# Altium schematic sheet ACDC.SchDoc
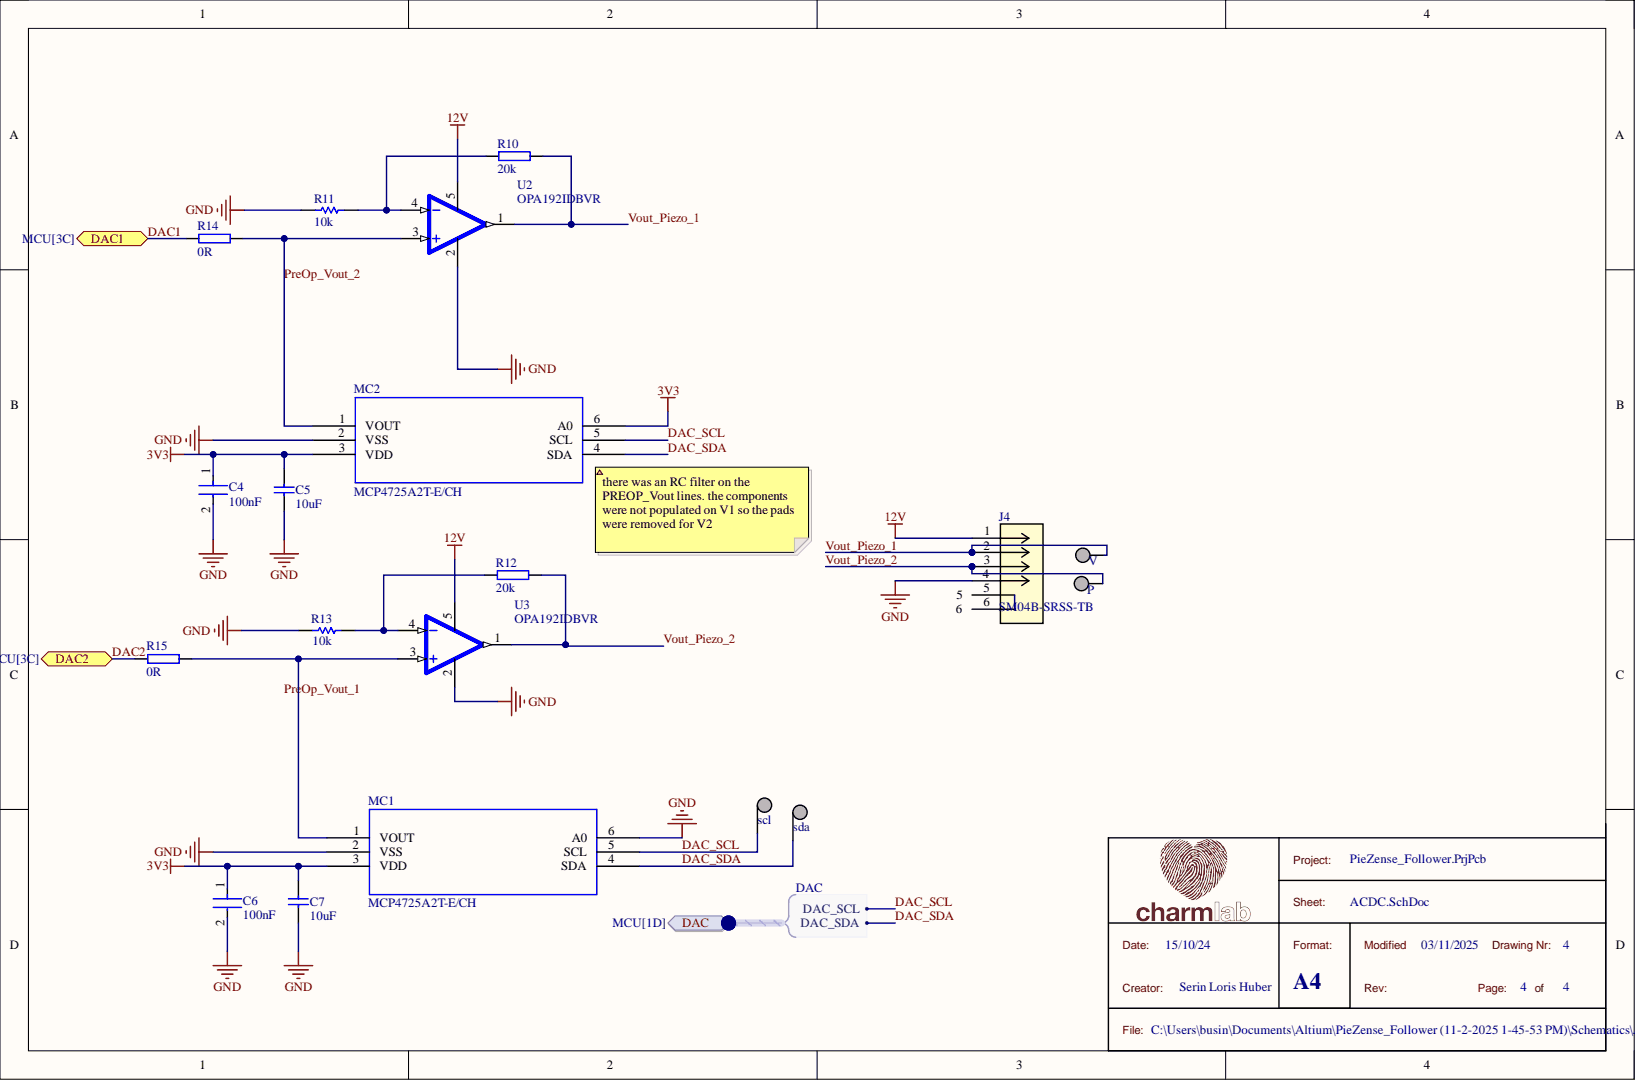
[diagram p0: ACDC.SchDoc]

## Parts
C4  100nF  [FP-GRM155-0_05-MFG]
C5  10uF  [CAP0402]
C6  100nF  [FP-GRM155-0_05-MFG]
C7  10uF  [CAP0402]
J4  SM04B-SRSS-TB  [CONN_SM04B-SRSS-TB_JST]
MC1  MCP4725A2T-E/CH  [SOT-23-6_MC]
MC2  MCP4725A2T-E/CH  [SOT-23-6_MC]
P  Test point  [TEST_POINT]  (value not drawn)  (no BOM)
R10  20k  [RES0402]
R11  10k  [RESC1005X40X25ML05T10]
R12  20k  [RES0402]
R13  10k  [RESC1005X40X25ML05T10]
R14  0R  [RES0402]
R15  0R  [RES0402]
scl  Test point  [TEST_POINT]  (value not drawn)  (no BOM)
sda  Test point  [TEST_POINT]  (value not drawn)  (no BOM)
U2  OPA192IDBVR  [SOT95P280X145-5N]
U3  OPA192IDBVR  [SOT95P280X145-5N]
V  Test point  [TEST_POINT]  (value not drawn)  (no BOM)

## Ports
DAC, DAC1, DAC2, DAC.DAC_SCL, DAC.DAC_SDA

## Nets
3V3: C4.1 C5.2 C6.1 C7.2 MC1.3(VDD) MC2.3(VDD) MC2.6(A0)
12V: J4.1 U2.5 U3.5
GND: C4.2 C5.1 C6.2 C7.1 J4.4 MC1.2(VSS) MC1.6(A0) MC2.2(VSS) R11.1 R13.1 U2.2 U3.2
DAC1: R14.1 ; port DAC1
DAC2: R15.1 ; port DAC2
DAC_SCL: MC1.5(SCL) MC2.5(SCL) scl.1 ; port DAC
DAC_SDA: MC1.4(SDA) MC2.4(SDA) sda.1 ; port DAC
PreOp_Vout_2: MC2.1(VOUT) R14.2 U2.3
Vout_Piezo_1: J4.2 R10.2 U2.1 V.1
Vout_Piezo_2: J4.3 P.1 R12.2 U3.1
NetJ4_5: J4.5 J4.6
NetMC1_1: MC1.1(VOUT) R15.2 U3.3
NetR10_1: R10.1 R11.2 U2.4
NetR12_1: R12.1 R13.2 U3.4
PreOp_Vout_1:

## Library data of the parts
C4 C6 = CMP-2008-04108-2: Chip Multilayer Ceramic Capacitors for General Purpose, 0402, 0.10uF, X5R, 15%, 10%, 50V; Capacitance: 100nF; Case Code (Imperial): 0402; Case Code (Metric): 1005; Case/Package: 0402; Component Kind: Standard; Depth: 0.5mm; Dielectric: X5R; Height: 0.5mm; Lead Free: Lead Free; Length: 1mm; Library Name: Altium Content Vault; Max Operating Temperature: 85°C; Min Operating Temperature: -55°C; Mount: Surface Mount; Packaging: Tape and Reel; REACH SVHC: No SVHC; Thickness: 0.022inch; Tolerance: 10%; Voltage: 50V; Voltage Rating: 50V; Voltage Rating (DC): 50V; Width: 0.02inch
C5 C7 = GRM155R61A106ME11D: CAP CER 10uF 10V X5R 0402; Manufacturer: Murata Electronics; Manufacturer Part Number: GRM155R71H103KA88D; Part Number: GRM033R70J103JA01D; Capacitor Type: Ceramic; Multilayer: Yes; Negative Tolerance: 10%; Rated DC Voltage (URdc): 50V; Temperature Characteristics Code: X7R; Tolerance: ±5%; Voltage - Rated: 6.3V; Applications: General Purpose; Capacitance: 10uF; Category: Ceramic Capacitors; Component Kind: Standard; ComponentLink1Description: Datasheet; ComponentLink1URL: https://search.murata.co.jp/Ceramy/image/img/A01X/G101/ENG/GRM033R70J103JA01-01.pdf; ComponentLink2Description: DigiKey Link; ComponentLink2URL: http://digikey.com/product-detail/en/murata-electronics/GRM033R70J103JA01D/490-16599-1-ND/7595523; ComponentLink3Description: https://psearch.en.murata.com:443/capacitor/product/GRM155R71H103KA88%23.pdf?src-supplier=IHS+Markit; ComponentLink3URL: https://psearch.en.murata.com:443/capacitor/product/GRM155R71H103KA88%23.pdf?src-supplier=IHS+Markit; ComponentLink4Description: https://datasheet.ciiva.com/22698/1747449-22698420.pdf?src-supplier=Element14; ComponentLink4URL: https://datasheet.ciiva.com/22698/1747449-22698420.pdf?src-supplier=Element14; ComponentLink5Description: https://datasheet.ciiva.com/19476/1856433-19476546.pdf?src-supplier=Jameco; ComponentLink5URL: https://datasheet.ciiva.com/19476/1856433-19476546.pdf?src-supplier=Jameco; ComponentLink6Description: https://datasheet.ciiva.com/129/product-129810.pdf?src-supplier=Digi-Key; ComponentLink6URL: https://datasheet.ciiva.com/129/product-129810.pdf?src-supplier=Digi-Key; ComponentLink7Description: https://datasheet.ciiva.com/11786/0900766b80ef921d-11786340.pdf?src-supplier=RSComponents; ComponentLink7URL: https://datasheet.ciiva.com/11786/0900766b80ef921d-11786340.pdf?src-supplier=RSComponents; ComponentLink8Description: https://datasheet.ciiva.com/18100/getdatasheetpartid-27434-18100660.pdf?src-supplier=Verical; ComponentLink8URL: https://datasheet.ciiva.com/18100/getdatasheetpartid-27434-18100660.pdf?src-supplier=Verical; ComponentLink9Description: https://datasheet.ciiva.com/29199/454225-da-01-en-vs-kondensator-0402-29199740.pdf?src-supplier=Conrad; ComponentLink9URL: https://datasheet.ciiva.com/29199/454225-da-01-en-vs-kondensator-0402-29199740.pdf?src-supplier=Conrad; ComponentLink10Description: https://datasheet.ciiva.com/129/grm155r71h103ka88-01-129811.pdf?src-supplier=Digi-Key; ComponentLink10URL: https://datasheet.ciiva.com/129/grm155r71h103ka88-01-129811.pdf?src-supplier=Digi-Key; ComponentLink11Description: https://datasheet.ciiva.com/129/grm155r71h103ka88-01-129812.pdf?src-supplier=Digi-Key; ComponentLink11URL: https://datasheet.ciiva.com/129/grm155r71h103ka88-01-129812.pdf?src-supplier=Digi-Key; ComponentLink12Description: https://datasheet.ciiva.com/5410/product-5410990.pdf?src-supplier=Mouser; ComponentLink12URL: https://datasheet.ciiva.com/5410/product-5410990.pdf?src-supplier=Mouser; ComponentLink13Description: https://datasheet.ciiva.com/16495/product-16495290.pdf?src-supplier=Future+Electronics; ComponentLink13URL: https://datasheet.ciiva.com/16495/product-16495290.pdf?src-supplier=Future+Electronics; ComponentLink14Description: https://datasheet.ciiva.com:443/pdfs/VipMasterIC/IC/MURE/MURES89421/MURES89636-1.pdf?src-supplier=IHS+Markit; ComponentLink14URL: https://datasheet.ciiva.com:443/pdfs/VipMasterIC/IC/MURE/MURES89421/MURES89636-1.pdf?src-supplier=IHS+Markit; ComponentLink15Description: https://datasheet.ciiva.com/6073/c02e-6073016.pdf?src-supplier=Farnell; ComponentLink15URL: https://datasheet.ciiva.com/6073/c02e-6073016.pdf?src-supplier=Farnell; ComponentLink16Description: https://datasheet.ciiva.com/22619/c02e-22619672.pdf?src-supplier=Element14; ComponentLink16URL: https://datasheet.ciiva.com/22619/c02e-22619672.pdf?src-supplier=Element14; ComponentLink17Description: https://datasheet.ciiva.com:443/pdfs/VipMasterIC/IC/MURE/MURE-S-A0006500741/MURE-S-A0006500741-1.pdf?src-supplier=IHS+Markit; ComponentLink17URL: https://datasheet.ciiva.com:443/pdfs/VipMasterIC/IC/MURE/MURE-S-A0006500741/MURE-S-A0006500741-1.pdf?src-supplier=IHS+Markit; Device Package: 0201 (0603 Metric); Dielectric Material: Ceramic; Height: 0.5mm; JESD-609 Code: e3; LastUpdated: 02/09/2020 01:00:04; Length: 1mm; Lifecycle Status: Active; Max Operating Temperature: 125°C; Min Operating Temperature: -55°C; Minimum Order: 1; Mount: Surface Mount; Mounting Type: Surface Mount, MLCC; Number of Pins: 2; Operating Temperature: -55°C ~ 125°C; Package / Case: 0201 (0603 Metric); Package Shape: Rectangular; Package Style: SMTMeter; Packaging: CutTape; Part Status: Active; PartId: 174; Positive Tolerance: 10%; Price: 0.10; Pricing 1: 1=0.12000, 10=0.08200, 50=0.08200, 100=0.04800, 1000=0.04800, 10000=0.04700 (USD); Pricing 2: 1=0.16000, 10=0.07100, 50=0.07100, 100=0.05400, 1000=0.03300, 10000=0.02500 (USD); REACH SVHC: Yes; Reach SVHC Compliant: Yes; RoHS: Yes; RoHS Compliant: Yes; Series: GRM; Size / Dimension: 0.024" L x 0.012" W (0.60mm x 0.30mm); Size Code: 0402; Stock 1: 1376480; Stock 2: 855140; Supplier 1: Mouser; Supplier 2: Mouser; Supplier 3: Digikey; Supplier 4: Digikey; Supplier 5: Digikey; Supplier Part Number 1: 81-GRM155R61A106ME1D; Supplier Part Number 2: 81-GRM188R60J106ME47; Supplier Part Number 3: 490-3896-1-ND; Supplier Part Number 4: 490-3896-6-ND; Supplier Part Number 5: 490-3896-2-ND; Temperature Coefficient: X7R; Terminal Finish: Nickel; Termination: Wraparound; Thickness (Max): 0.013" (0.33mm); Value: 10uF; Width: 0.5mm; Library Name: schuluka.SCHLIB
J4 = CMP-008-000001-3: No Description Available; Mfr_Name: JST; Component Kind: Standard; Design Item ID: SM04B-SRSS-TB; RefDes: RefDes; Source: SM04B-SRSS-TB.SchLib; Type: Type; Library Name: CHARM_PieZense
MC1 MC2 = MCP4725A2T-E/CH: 12 Bit Digital to Analog Converter 1 SOT-23-6; MF: Microchip; Availability: Unavailable; Component Kind: Standard; MP: MCP4725A2T-E/CH; Package: SOT-23-6 Microchip; Price: None; SnapEDA_Link: https://www.snapeda.com/parts/MCP4725A2T-E/CH/Microchip/view-part/108042/?ref=snap; Supplier 1: Digikey; Supplier 2: Digikey; Supplier 3: Digikey; Supplier 4: Mouser; Supplier Part Number 1: MCP4725A2T-E/CHCT-ND; Supplier Part Number 2: MCP4725A2T-E/CHDKR-ND; Supplier Part Number 3: MCP4725A2T-E/CHTR-ND; Supplier Part Number 4: 579-MCP4725A2T-E/CH; Library Name: huberser.SchLib
R10 R12 = CRCW040220K0FKEDC: RES SMD 20k OHM  0.063W 0402; Manufacturer: Panasonic Electronic Components; Manufacturer Part Number: ERJ-1GNJ334C; Part Number: ERJ-1GNF5110C; Package Height: 0.35mm; Package Length: 1mm; Package Width: 0.5mm; Power (Watts): 0.05W, 1/20W; Rated Power Dissipation (P): 63mW; Rated Temperature: 70°C; Reference Standard: AEC-Q200; Resistance: 20k Ohms; Temperature Coefficient: ±200ppm/°C; Tolerance: ±1%; Working Voltage: 50V; Category: Chip SMD Resistors; Component Kind: Standard; ComponentLink1Description: Datasheet; ComponentLink1URL: https://industrial.panasonic.com/cdbs/www-data/pdf/RDA0000/AOA0000C304.pdf; ComponentLink2Description: DigiKey Link; ComponentLink2URL: http://digikey.com/product-detail/en/panasonic-electronic-components/ERJ-1GNF5110C/P123054CT-ND/8343047; ComponentLink3Description: http://www.onlinecomponents.com/datasheet/erj-1gnj334c.aspx?p=44467782&src-supplier=Onlinecomponents.com; ComponentLink3URL: http://www.onlinecomponents.com/datasheet/erj-1gnj334c.aspx?p=44467782&src-supplier=Onlinecomponents.com; ComponentLink4Description: https://b2b-api.panasonic.eu/file_stream/pids/fileversion/1242?src-supplier=Digi-Key; ComponentLink4URL: https://b2b-api.panasonic.eu/file_stream/pids/fileversion/1242?src-supplier=Digi-Key; ComponentLink5Description: http://industrial.panasonic.com/www-cgi/jvcr13pz.cgi?E+PZ+3+AOA0002+ERJ1GNJ334C+7+WW?src-supplier=Digi-Key; ComponentLink5URL: http://industrial.panasonic.com/www-cgi/jvcr13pz.cgi?E+PZ+3+AOA0002+ERJ1GNJ334C+7+WW?src-supplier=Digi-Key; ComponentLink6Description: http://industrial.panasonic.com/www-cgi/jvcr13pz.cgi?E+PZ+3+AOA0002+ERJ1GNJ120C+7+WW?src-supplier=Mouser; ComponentLink6URL: http://industrial.panasonic.com/www-cgi/jvcr13pz.cgi?E+PZ+3+AOA0002+ERJ1GNJ120C+7+WW?src-supplier=Mouser; ComponentLink7Description: https://datasheet.ciiva.com:443/pdfs/VipMasterIC/IC/VISH/VISH-S-A0007266705/VISH-S-A0007266705-1.pdf?src-supplier=IHS+Markit; ComponentLink7URL: https://datasheet.ciiva.com:443/pdfs/VipMasterIC/IC/VISH/VISH-S-A0007266705/VISH-S-A0007266705-1.pdf?src-supplier=IHS+Markit; ComponentLink8Description: https://datasheet.ciiva.com/2461/dcrcwe3-2461974.pdf?src-supplier=Digi-Key; ComponentLink8URL: https://datasheet.ciiva.com/2461/dcrcwe3-2461974.pdf?src-supplier=Digi-Key; ComponentLink9Description: https://datasheet.ciiva.com/2461/dcrcwe3-2461975.pdf?src-supplier=Digi-Key; ComponentLink9URL: https://datasheet.ciiva.com/2461/dcrcwe3-2461975.pdf?src-supplier=Digi-Key; ComponentLink10Description: https://datasheet.ciiva.com/2461/dcrcwe3-2461976.pdf?src-supplier=Digi-Key; ComponentLink10URL: https://datasheet.ciiva.com/2461/dcrcwe3-2461976.pdf?src-supplier=Digi-Key; ComponentLink11Description: https://datasheet.ciiva.com/7743/dcrcwe3-7743416.pdf?src-supplier=Element14; ComponentLink11URL: https://datasheet.ciiva.com/7743/dcrcwe3-7743416.pdf?src-supplier=Element14; ComponentLink12Description: https://datasheet.ciiva.com/16771/70201119-16771096.pdf?src-supplier=Allied+Electronics; ComponentLink12URL: https://datasheet.ciiva.com/16771/70201119-16771096.pdf?src-supplier=Allied+Electronics; ComponentLink13Description: https://datasheet.ciiva.com/7743/dcrcwe3-7743417.pdf?src-supplier=Element14; ComponentLink13URL: https://datasheet.ciiva.com/7743/dcrcwe3-7743417.pdf?src-supplier=Element14; ComponentLink14Description: https://datasheet.ciiva.com/16761/70201119-16761947.pdf?src-supplier=Allied+Electronics; ComponentLink14URL: https://datasheet.ciiva.com/16761/70201119-16761947.pdf?src-supplier=Allied+Electronics; ComponentLink15Description: https://datasheet.ciiva.com/3255/dcrcwe3-3255964.pdf?src-supplier=Avnet; ComponentLink15URL: https://datasheet.ciiva.com/3255/dcrcwe3-3255964.pdf?src-supplier=Avnet; ComponentLink16Description: https://datasheet.ciiva.com/3255/dcrcwe3-3255965.pdf?src-supplier=Avnet; ComponentLink16URL: https://datasheet.ciiva.com/3255/dcrcwe3-3255965.pdf?src-supplier=Avnet; ComponentLink17Description: https://datasheet.ciiva.com:443/pdfs/VipMasterIC/IC/VISH/VISH-S-A0003599164/VISH-S-A0003599164-1.pdf?src-supplier=IHS+Markit; ComponentLink17URL: https://datasheet.ciiva.com:443/pdfs/VipMasterIC/IC/VISH/VISH-S-A0003599164/VISH-S-A0003599164-1.pdf?src-supplier=IHS+Markit; ComponentLink18Description: http://www.vishay.com/docs/28773/crcwce3.pdf?src-supplier=Digi-Key; ComponentLink18URL: http://www.vishay.com/docs/28773/crcwce3.pdf?src-supplier=Digi-Key; Composition: Thick Film; Construction: Chip; Device Package: 0201 (0603 Metric); Features: Automotive AEC-Q200; Height - Seated (Max): 0.010" (0.26mm); JESD-609 Code: e3; LastUpdated: 02/09/2020 01:06:20; Lifecycle Status: Active; Max Operating Temperature: 155°C; Min Operating Temperature: -55°C; Minimum Order: 1; Mount: Surface Mount; Number of Pins: 2; Number of Terminations: 2; Operating Temperature: -55°C ~ 125°C; Package / Case: 0201 (0603 Metric); Package Shape: Rectangular; Package Style: SMTMeter; Packaging: TapeAndReel; Part Status: Active; PartId: 1262; Price: 0.01; Pricing 1: 1=0.10000, 10=0.03500, 50=0.03500, 100=0.01500, 1000=0.00700, 2500=0.00600, 5000=0.00500, 10000=0.00500 (USD); Pricing 2: 1=0.10000, 10=0.03400, 50=0.03400, 100=0.01400, 1000=0.00700, 2500=0.00600, 5000=0.00500, 10000=0.00400 (USD); Ratings: AEC-Q200; REACH SVHC: Yes; Resistor Type: FIXED RESISTOR; RoHS: Yes; RoHS Compliant: Yes; Series: ERJ; Size / Dimension: 0.024" L x 0.012" W (0.60mm x 0.30mm); Size Code: 0402; Stock 2: 9502; Supplier 1: Mouser; Supplier Device Package: 0201; Supplier Part Number 1: 71-CRCW040220K0FKEDC; Technology: METAL GLAZE/THICK FILM; Terminal Finish: Nickel; Termination: Wraparound; Value: 20k; Library Name: schuluka.SCHLIB
  R12: Supplier 2: Mouser; Supplier 3: Digikey; Supplier 4: Digikey; Supplier 5: Digikey; Supplier Part Number 2: 667-ERA-3AEB203V; Supplier Part Number 3: P20KDBCT-ND; Supplier Part Number 4: P20KDBDKR-ND; Supplier Part Number 5: P20KDBTR-ND
R11 R13 = CMP-2002-03043-1: Manufacturer: Vishay Dale; Part Number: CRCW040210K0FKEDHP; Component Kind: Standard; Library Name: Altium Content Vault
R14 R15 = CRCW04020000Z0EDC: RES SMD 0 OHM  0.063W 0402; Manufacturer: Panasonic Electronic Components; Manufacturer Part Number: ERJ-1GNJ334C; Part Number: ERJ-1GNF5110C; Package Height: 0.35mm; Package Length: 1mm; Package Width: 0.5mm; Power (Watts): 0.05W, 1/20W; Rated Power Dissipation (P): 63mW; Rated Temperature: 70°C; Reference Standard: AEC-Q200; Resistance: 0R Ohms; Temperature Coefficient: ±200ppm/°C; Tolerance: ±1%; Working Voltage: 50V; Category: Chip SMD Resistors; Component Kind: Standard; ComponentLink1Description: Datasheet; ComponentLink1URL: https://industrial.panasonic.com/cdbs/www-data/pdf/RDA0000/AOA0000C304.pdf; ComponentLink2Description: DigiKey Link; ComponentLink2URL: http://digikey.com/product-detail/en/panasonic-electronic-components/ERJ-1GNF5110C/P123054CT-ND/8343047; ComponentLink3Description: http://www.onlinecomponents.com/datasheet/erj-1gnj334c.aspx?p=44467782&src-supplier=Onlinecomponents.com; ComponentLink3URL: http://www.onlinecomponents.com/datasheet/erj-1gnj334c.aspx?p=44467782&src-supplier=Onlinecomponents.com; ComponentLink4Description: https://b2b-api.panasonic.eu/file_stream/pids/fileversion/1242?src-supplier=Digi-Key; ComponentLink4URL: https://b2b-api.panasonic.eu/file_stream/pids/fileversion/1242?src-supplier=Digi-Key; ComponentLink5Description: http://industrial.panasonic.com/www-cgi/jvcr13pz.cgi?E+PZ+3+AOA0002+ERJ1GNJ334C+7+WW?src-supplier=Digi-Key; ComponentLink5URL: http://industrial.panasonic.com/www-cgi/jvcr13pz.cgi?E+PZ+3+AOA0002+ERJ1GNJ334C+7+WW?src-supplier=Digi-Key; ComponentLink6Description: http://industrial.panasonic.com/www-cgi/jvcr13pz.cgi?E+PZ+3+AOA0002+ERJ1GNJ120C+7+WW?src-supplier=Mouser; ComponentLink6URL: http://industrial.panasonic.com/www-cgi/jvcr13pz.cgi?E+PZ+3+AOA0002+ERJ1GNJ120C+7+WW?src-supplier=Mouser; ComponentLink7Description: https://datasheet.ciiva.com:443/pdfs/VipMasterIC/IC/VISH/VISH-S-A0007266705/VISH-S-A0007266705-1.pdf?src-supplier=IHS+Markit; ComponentLink7URL: https://datasheet.ciiva.com:443/pdfs/VipMasterIC/IC/VISH/VISH-S-A0007266705/VISH-S-A0007266705-1.pdf?src-supplier=IHS+Markit; ComponentLink8Description: https://datasheet.ciiva.com/11808/0900766b80d98824-11808638.pdf?src-supplier=RSComponents; ComponentLink8URL: https://datasheet.ciiva.com/11808/0900766b80d98824-11808638.pdf?src-supplier=RSComponents; ComponentLink9Description: https://datasheet.ciiva.com/2463/dcrcwe3-2463030.pdf?src-supplier=Digi-Key; ComponentLink9URL: https://datasheet.ciiva.com/2463/dcrcwe3-2463030.pdf?src-supplier=Digi-Key; ComponentLink10Description: https://datasheet.ciiva.com/46385/1697337-46385499.pdf?src-supplier=Element14; ComponentLink10URL: https://datasheet.ciiva.com/46385/1697337-46385499.pdf?src-supplier=Element14; ComponentLink11Description: https://datasheet.ciiva.com/3256/dcrcwe3-3256140.pdf?src-supplier=Avnet; ComponentLink11URL: https://datasheet.ciiva.com/3256/dcrcwe3-3256140.pdf?src-supplier=Avnet; ComponentLink12Description: https://datasheet.ciiva.com/16721/70201119-16721452.pdf?src-supplier=Allied+Electronics; ComponentLink12URL: https://datasheet.ciiva.com/16721/70201119-16721452.pdf?src-supplier=Allied+Electronics; ComponentLink13Description: https://datasheet.ciiva.com/2463/dcrcwe3-2463028.pdf?src-supplier=Digi-Key; ComponentLink13URL: https://datasheet.ciiva.com/2463/dcrcwe3-2463028.pdf?src-supplier=Digi-Key; ComponentLink14Description: https://datasheet.ciiva.com/2463/dcrcwe3-2463029.pdf?src-supplier=Digi-Key; ComponentLink14URL: https://datasheet.ciiva.com/2463/dcrcwe3-2463029.pdf?src-supplier=Digi-Key; ComponentLink15Description: https://datasheet.ciiva.com/7743/dcrcwe3-7743477.pdf?src-supplier=Element14; ComponentLink15URL: https://datasheet.ciiva.com/7743/dcrcwe3-7743477.pdf?src-supplier=Element14; ComponentLink16Description: https://datasheet.ciiva.com/26316/fld-crcw-e3-data-en-26316646.pdf?src-supplier=Distrelec; ComponentLink16URL: https://datasheet.ciiva.com/26316/fld-crcw-e3-data-en-26316646.pdf?src-supplier=Distrelec; ComponentLink17Description: https://datasheet.ciiva.com/3256/dcrcwe3-3256139.pdf?src-supplier=Avnet; ComponentLink17URL: https://datasheet.ciiva.com/3256/dcrcwe3-3256139.pdf?src-supplier=Avnet; ComponentLink18Description: https://datasheet.ciiva.com/16287/dcrcwe3-16287807.pdf?src-supplier=Future+Electronics; ComponentLink18URL: https://datasheet.ciiva.com/16287/dcrcwe3-16287807.pdf?src-supplier=Future+Electronics; ComponentLink19Description: https://datasheet.ciiva.com:443/pdfs/VipMasterIC/IC/VISH/VISH-S-A0003599164/VISH-S-A0003599164-1.pdf?src-supplier=IHS+Markit; ComponentLink19URL: https://datasheet.ciiva.com:443/pdfs/VipMasterIC/IC/VISH/VISH-S-A0003599164/VISH-S-A0003599164-1.pdf?src-supplier=IHS+Markit; ComponentLink20Description: http://www.vishay.com/docs/28773/crcwce3.pdf?src-supplier=Digi-Key; ComponentLink20URL: http://www.vishay.com/docs/28773/crcwce3.pdf?src-supplier=Digi-Key; ComponentLink21Description: http://www.vishay.com/docs/20035/dcrcwe3.pdf?src-supplier=Avnet; ComponentLink21URL: http://www.vishay.com/docs/20035/dcrcwe3.pdf?src-supplier=Avnet; Composition: Thick Film; Construction: Chip; Device Package: 0201 (0603 Metric); Features: Automotive AEC-Q200; Height - Seated (Max): 0.010" (0.26mm); JESD-609 Code: e3; LastUpdated: 02/09/2020 01:06:20; Lifecycle Status: Active; Max Operating Temperature: 155°C; Min Operating Temperature: -55°C; Minimum Order: 1; Mount: Surface Mount; Number of Pins: 2; Number of Terminations: 2; Operating Temperature: -55°C ~ 125°C; Package / Case: 0201 (0603 Metric); Package Shape: Rectangular; Package Style: SMTMeter; Packaging: TapeAndReel; Part Status: Active; PartId: 1262; Price: 0.01; Pricing 1: 1=0.10000, 10=0.02300, 100=0.00950, 1000=0.00427, 2500=0.00370, 5000=0.00306 (USD); Pricing 2: 1=0.10000, 10=0.03200, 50=0.03200, 100=0.01300, 1000=0.00600, 10000=0.00400 (USD); Ratings: AEC-Q200; REACH SVHC: Yes; Resistor Type: FIXED RESISTOR; RoHS: Yes; RoHS Compliant: Yes; Series: ERJ; Size / Dimension: 0.024" L x 0.012" W (0.60mm x 0.30mm); Size Code: 0402; Stock 1: 723641; Stock 2: 32600; Supplier 1: Digi-Key; Supplier Device Package: 0201; Supplier Part Number 1: 541-4062-1-ND; Technology: METAL GLAZE/THICK FILM; Terminal Finish: Nickel; Termination: Wraparound; Value: 0R; Library Name: schuluka.SCHLIB
U2 U3 = OPA192IDBVR: Single, 14MHz, MUX-friendly, low-noise, Zero-Drift, RRO, CMOS precision operational amplifier 5-SOT-23 -40 to 125; Availability: Unavailable; Component Kind: Standard; MP: OPA192IDBVR; Package: None; Price: None; Supplier 1: Mouser; Supplier 2: Digikey; Supplier 3: Digikey; Supplier 4: Digikey; Supplier Part Number 1: 595-OPA192IDBVR; Supplier Part Number 2: 296-42105-1-ND; Supplier Part Number 3: 296-42105-6-ND; Supplier Part Number 4: 296-42105-2-ND; Library Name: huberser.SchLib
P scl sda V = Test point: Library Name: schuluka.SCHLIB
